# Altium schematic sheet Simulation-Sheet.SchDoc
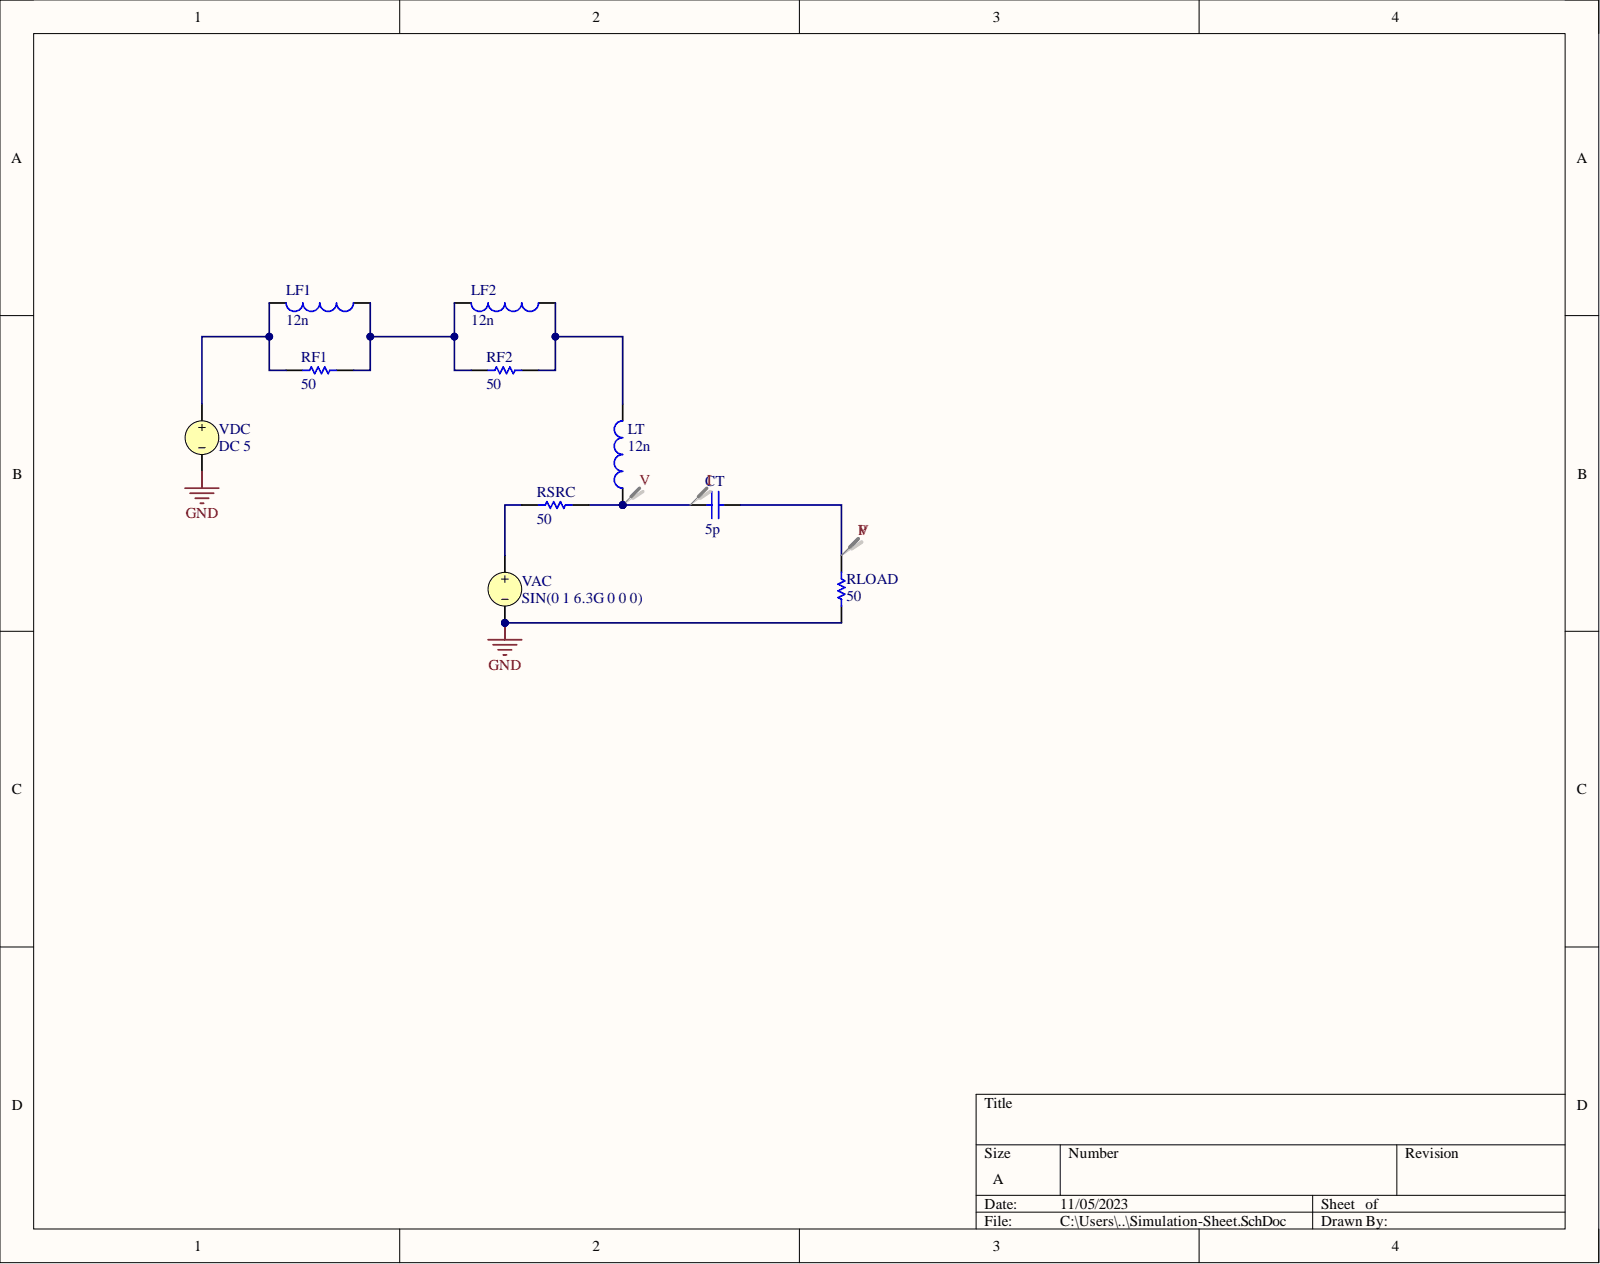
[diagram p0: Simulation-Sheet.SchDoc]

## Parts
CT  value 5p  (hidden comment: Capacitor)
LF1  value 12n  (hidden comment: Inductor)
LF2  value 12n  (hidden comment: Inductor)
LT  value 12n  (hidden comment: Inductor)
RF1  value 50  (hidden comment: Resistor)
RF2  value 50  (hidden comment: Resistor)
RLOAD  value 50  (hidden comment: Resistor)
RSRC  value 50  (hidden comment: Resistor)
VAC  SIN(0 1 6.3G 0 0 0)
VDC  DC 5  value 5

## Nets
GND: RLOAD.1 VAC.2 VDC.2
NetCT_1: CT.1 LT.1 RSRC.1
NetCT_2: CT.2 RLOAD.2
NetLF1_1: LF1.1 LF2.2 RF1.1 RF2.2
NetLF1_2: LF1.2 RF1.2 VDC.1
NetLF2_1: LF2.1 LT.2 RF2.1
NetRSRC_2: RSRC.2 VAC.1

## Library data of the parts
CT = Capacitor: SimulationGenericComponent: True; Component Kind: Standard; Description: Capacitor; Library Name: Simulation Generic Components.IntLib; Simulation: C_Capacitor_Default
LF1 LF2 LT = Inductor: SimulationGenericComponent: True; Component Kind: Standard; Description: Inductor; Library Name: Simulation Generic Components.IntLib; Simulation: L_Inductor_Default
RF1 RF2 RLOAD RSRC = Resistor: SimulationGenericComponent: True; TC1: 0; Component Kind: Standard; Description: Resistor; Library Name: Simulation Generic Components.IntLib; Simulation: R_Resistor_Default; TC2: 0
VAC VDC = VSRC: Voltage Source; AC Magnitude: 1; AC Phase: 0; Note: PCB Footprint - Not required; Component Kind: Standard; LatestRevisionDate: 17-Jul-2002; LatestRevisionNote: Re-released for DXP Platform.; Library Name: Simulation Generic Components.IntLib; PackageReference: Not Applicable; Published: 8-Jun-2000; Simulation: VSRC; Publisher: Altium Limited
  VAC: Amplitude: 1; Damping Factor: 0; DC Magnitude: 0; Delay: 0; Frequency: 6.3G; Offset: 0; Phase: 0
